# Altium schematic sheet B2B_Connector.SchDoc(B2B_Connector)
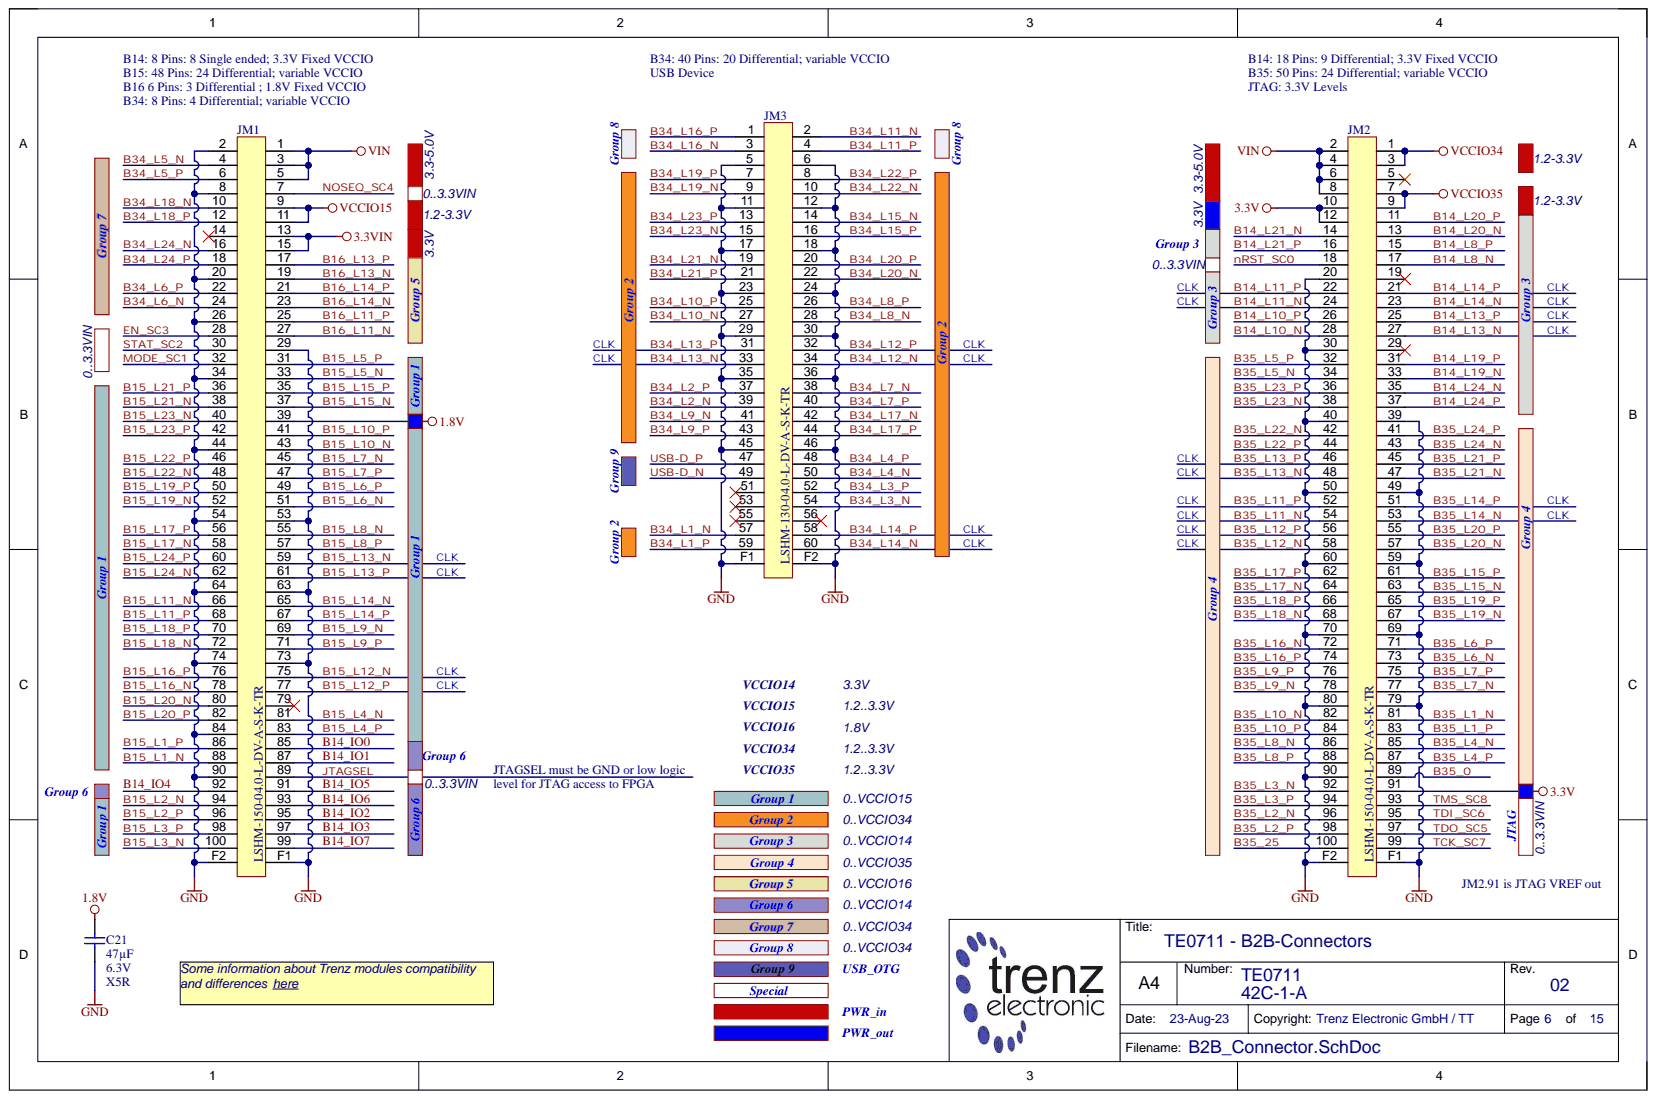
[diagram p0: B2B_Connector.SchDoc]

## Parts
C21  47µF  Technology: X5R  Voltage: 6.3V  [CAPC2012X135N_V]
JM1  LSHM-150-04.0-L-DV-A-S-K-TR  [LSHM-150-XXX-X-DV-A-X]
JM2  LSHM-150-04.0-L-DV-A-S-K-TR  [LSHM-150-XXX-X-DV-A-X]
JM3  LSHM-130-04.0-L-DV-A-S-K-TR  [LSHM-130-04.0-x-DV-A-S-K-TR]

## Nets
1.8V: C21.1 JM1.39
3.3V: JM2.10 JM2.12 JM2.91
3.3VIN: JM1.13 JM1.15
GND: C21.2 JM1.2 JM1.8 JM1.20 JM1.26 JM1.29 JM1.34 JM1.44 JM1.53 JM1.54 JM1.63 JM1.64 JM1.73 JM1.74 JM1.84 JM1.90 JM1.F1 JM1.F2 JM2.20 JM2.30 JM2.39 JM2.40 JM2.49 JM2.50 JM2.59 JM2.60 JM2.69 JM2.70 JM2.79 JM2.80 JM2.90 JM2.F1 JM2.F2 JM3.5 JM3.6 JM3.11 JM3.12 JM3.17 JM3.18 JM3.23 JM3.24 JM3.29 JM3.30 JM3.35 JM3.36 JM3.45 JM3.46 JM3.F1 JM3.F2
VCCIO15: JM1.9 JM1.11
VCCIO34: JM2.1 JM2.3
VCCIO35: JM2.7 JM2.9
VIN: JM1.1 JM1.3 JM1.5 JM2.2 JM2.4 JM2.6 JM2.8
B14_IO0: JM1.85
B14_IO1: JM1.87
B14_IO2: JM1.95
B14_IO3: JM1.97
B14_IO4: JM1.92
B14_IO5: JM1.91
B14_IO6: JM1.93
B14_IO7: JM1.99
B14_L8_N: JM2.17
B14_L8_P: JM2.15
B14_L10_N: JM2.28
B14_L10_P: JM2.26
B14_L11_N: JM2.24
B14_L11_P: JM2.22
B14_L13_N: JM2.27
B14_L13_P: JM2.25
B14_L14_N: JM2.23
B14_L14_P: JM2.21
B14_L19_N: JM2.33
B14_L19_P: JM2.31
B14_L20_N: JM2.13
B14_L20_P: JM2.11
B14_L21_N: JM2.14
B14_L21_P: JM2.16
B14_L24_N: JM2.35
B14_L24_P: JM2.37
B15_L1_N: JM1.88
B15_L1_P: JM1.86
B15_L2_N: JM1.94
B15_L2_P: JM1.96
B15_L3_N: JM1.100
B15_L3_P: JM1.98
B15_L4_N: JM1.81
B15_L4_P: JM1.83
B15_L5_N: JM1.33
B15_L5_P: JM1.31
B15_L6_N: JM1.51
B15_L6_P: JM1.49
B15_L7_N: JM1.45
B15_L7_P: JM1.47
B15_L8_N: JM1.55
B15_L8_P: JM1.57
B15_L9_N: JM1.69
B15_L9_P: JM1.71
B15_L10_N: JM1.43
B15_L10_P: JM1.41
B15_L11_N: JM1.66
B15_L11_P: JM1.68
B15_L12_N: JM1.75
B15_L12_P: JM1.77
B15_L13_N: JM1.59
B15_L13_P: JM1.61
B15_L14_N: JM1.65
B15_L14_P: JM1.67
B15_L15_N: JM1.37
B15_L15_P: JM1.35
B15_L16_N: JM1.78
B15_L16_P: JM1.76
B15_L17_N: JM1.58
B15_L17_P: JM1.56
B15_L18_N: JM1.72
B15_L18_P: JM1.70
B15_L19_N: JM1.52
B15_L19_P: JM1.50
B15_L20_N: JM1.80
B15_L20_P: JM1.82
B15_L21_N: JM1.38
B15_L21_P: JM1.36
B15_L22_N: JM1.48
B15_L22_P: JM1.46
B15_L23_N: JM1.40
B15_L23_P: JM1.42
B15_L24_N: JM1.62
B15_L24_P: JM1.60
B16_L11_N: JM1.27
B16_L11_P: JM1.25
B16_L13_N: JM1.19
B16_L13_P: JM1.17
B16_L14_N: JM1.23
B16_L14_P: JM1.21
B34_L1_N: JM3.57
B34_L1_P: JM3.59
B34_L2_N: JM3.39
B34_L2_P: JM3.37
B34_L3_N: JM3.54
B34_L3_P: JM3.52
B34_L4_N: JM3.50
B34_L4_P: JM3.48
B34_L5_N: JM1.4
B34_L5_P: JM1.6
B34_L6_N: JM1.24
B34_L6_P: JM1.22
B34_L7_N: JM3.38
B34_L7_P: JM3.40
B34_L8_N: JM3.28
B34_L8_P: JM3.26
B34_L9_N: JM3.41
B34_L9_P: JM3.43
B34_L10_N: JM3.27
B34_L10_P: JM3.25
B34_L11_N: JM3.2
B34_L11_P: JM3.4
B34_L12_N: JM3.34
B34_L12_P: JM3.32
B34_L13_N: JM3.33
B34_L13_P: JM3.31
B34_L14_N: JM3.60
B34_L14_P: JM3.58
B34_L15_N: JM3.14
B34_L15_P: JM3.16
B34_L16_N: JM3.3
B34_L16_P: JM3.1
B34_L17_N: JM3.42
B34_L17_P: JM3.44
B34_L18_N: JM1.10
B34_L18_P: JM1.12
B34_L19_N: JM3.9
B34_L19_P: JM3.7
B34_L20_N: JM3.22
B34_L20_P: JM3.20
B34_L21_N: JM3.19
B34_L21_P: JM3.21
B34_L22_N: JM3.10
B34_L22_P: JM3.8
B34_L23_N: JM3.15
B34_L23_P: JM3.13
B34_L24_N: JM1.16
B34_L24_P: JM1.18
B35_0: JM2.89
B35_25: JM2.100
B35_L1_N: JM2.81
B35_L1_P: JM2.83
B35_L2_N: JM2.96
B35_L2_P: JM2.98
B35_L3_N: JM2.92
B35_L3_P: JM2.94
B35_L4_N: JM2.85
B35_L4_P: JM2.87
B35_L5_N: JM2.34
B35_L5_P: JM2.32
B35_L6_N: JM2.73
B35_L6_P: JM2.71
B35_L7_N: JM2.77
B35_L7_P: JM2.75
B35_L8_N: JM2.86
B35_L8_P: JM2.88
B35_L9_N: JM2.78
B35_L9_P: JM2.76
B35_L10_N: JM2.82
B35_L10_P: JM2.84
B35_L11_N: JM2.54
B35_L11_P: JM2.52
B35_L12_N: JM2.58
B35_L12_P: JM2.56
B35_L13_N: JM2.48
B35_L13_P: JM2.46
B35_L14_N: JM2.53
B35_L14_P: JM2.51
B35_L15_N: JM2.63
B35_L15_P: JM2.61
B35_L16_N: JM2.72
B35_L16_P: JM2.74
B35_L17_N: JM2.64
B35_L17_P: JM2.62
B35_L18_N: JM2.68
B35_L18_P: JM2.66
B35_L19_N: JM2.67
B35_L19_P: JM2.65
B35_L20_N: JM2.57
B35_L20_P: JM2.55
B35_L21_N: JM2.47
B35_L21_P: JM2.45
B35_L22_N: JM2.42
B35_L22_P: JM2.44
B35_L23_N: JM2.38
B35_L23_P: JM2.36
B35_L24_N: JM2.43
B35_L24_P: JM2.41
EN_SC3: JM1.28
JTAGSEL: JM1.89
MODE_SC1: JM1.32
NOSEQ_SC4: JM1.7
nRST_SC0: JM2.18
STAT_SC2: JM1.30
TCK_SC7: JM2.99
TDI_SC6: JM2.95
TDO_SC5: JM2.97
TMS_SC8: JM2.93
USB-D_N: JM3.49
USB-D_P: JM3.47

Not connected: JM1 14 79; JM2 5 19 29; JM3 51 53 55 56

## Library data of the parts
C21 = 24718: KON 47µF 6,3V X5R 20% SMD BF 2012 (0805); Database Table Name: C_2012_0805; H-ART: CC0805MKX5R5BB476; Mixed: SMT; Preferred: Yes; Temperature-Range: -55/+85; Value: 47u; AN: 24718; Case: 2012 (0805); Component Kind: Standard; Library Name: TE.DbLib; Tolerance: 20%
JM1 JM2 = 23838: Samtec Razor Beam REF-189016-02, LF-04.0, 100 Pins, für alle 4 x 5 SoMs; Database Table Name: CON_B2B; Mixed: SMT; Preferred: Yes; Temperature-Range: -55/+125 (Abs); AN: 23838; Component Kind: Standard; Extra: LSHM-150-04.0-L-DV-A-S-K-TR; H-ART: LSHM-150-04.0-L-DV-A-S-K-TR; Library Name: TE.DbLib; Trenz-Stock: Yes; HelpURL: B:\AD\adlib\datasheet\LSHM-1XX-XX-XXX-DV-A
JM3 = 24903: Samtec Razor Beam REF-189017-02, LF-04.0, 60 Pins, für alle 4 x 5 SoMs; Database Table Name: CON_B2B; Mixed: SMT; Temperature-Range: -55/+125; Trenz-Stock: Yes; AN: 24903; Component Kind: Standard; Extra: LSHM-130-04.0-L-DV-A-S-K-TR; H-ART: LSHM-130-04.0-L-DV-A-S-K-TR; Library Name: TE.DbLib; HelpURL: B:\AD\adlib\datasheet\LSHM-1XX-XX-XXX-DV-A
